# Altium schematic sheet node.SchDoc(NODE)
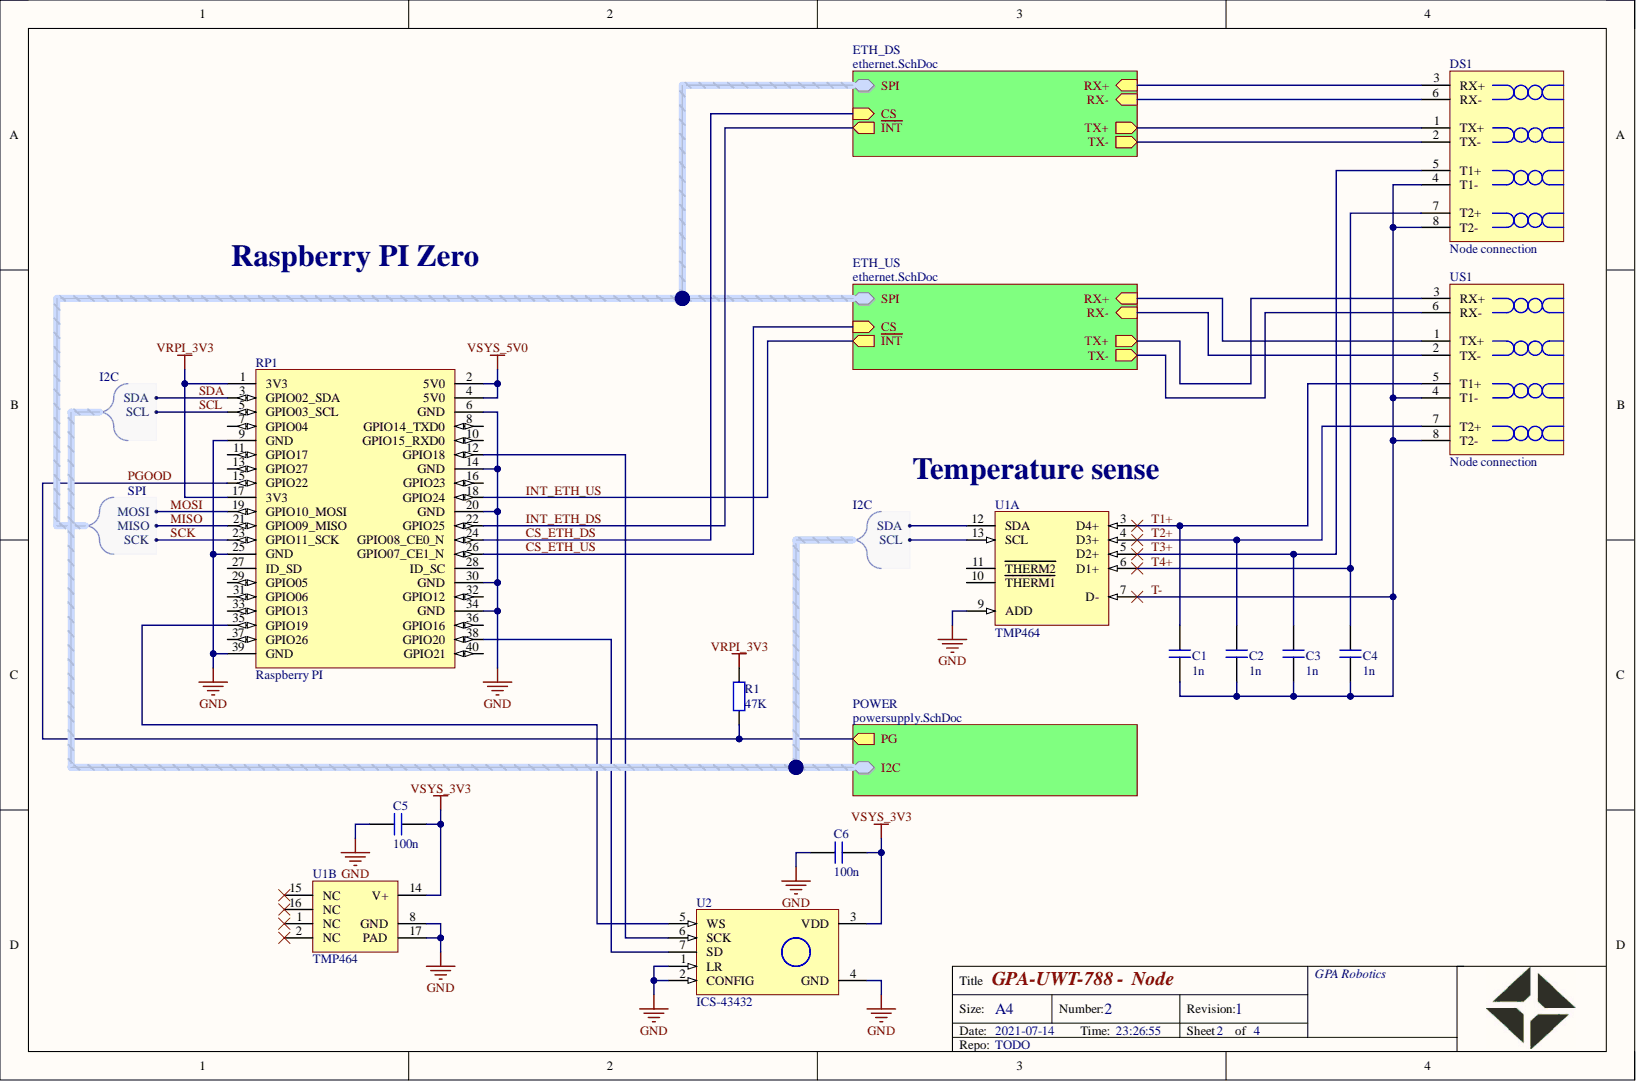
[diagram p0: node.SchDoc]

## Parts
C1  value 1n  [CAPC1005X06N]  (hidden comment: cap)
C2  value 1n  [CAPC1005X06N]  (hidden comment: cap)
C3  value 1n  [CAPC1005X06N]  (hidden comment: cap)
C4  value 1n  [CAPC1005X06N]  (hidden comment: cap)
C5  value 100n  [CAPC1005X06N]  (hidden comment: cap)
C6  value 100n  [CAPC1005X06N]  (hidden comment: cap)
DS1  Node connection  [node_connection]  (no BOM)
R1  value 47K  [RESC1005X04N]  (hidden comment: res)
RP1  Raspberry PI  [raspi_male_con]  (value not drawn)
U1  TMP464  [QFN50P300X300X100_HS-17N_TMP464]  (parts A,B)
U2  ICS-43432  [PSON65P300X110-6N]
US1  Node connection  [node_connection]  (no BOM)

## Nets
GND: C5.1 C6.1 RP1.6(GND) RP1.9(GND) RP1.14(GND) RP1.20(GND) RP1.25(GND) RP1.30(GND) RP1.34(GND) RP1.39(GND) U1.8(GND) U1.9(ADD) U1.17(PAD) U2.1(LR) U2.2(CONFIG) U2.4(GND)
VRPI_3V3: R1.2 RP1.1(3V3) RP1.17(3V3)
VSYS_3V3: C5.2 C6.2 U1.14(V+) U2.3(VDD)
VSYS_5V0: RP1.2(5V0) RP1.4(5V0)
CS_ETH_DS: RP1.24(GPIO08_CE0_N)
CS_ETH_US: RP1.26(GPIO07_CE1_N)
INT_ETH_DS: RP1.22(GPIO25)
INT_ETH_US: RP1.18(GPIO24)
MISO: RP1.21(GPIO09_MISO)
MOSI: RP1.19(GPIO10_MOSI)
PGOOD: R1.1 RP1.15(GPIO22)
SCK: RP1.23(GPIO11_SCK)
SCL: RP1.5(GPIO03_SCL) U1.13(SCL)
SDA: RP1.3(GPIO02_SDA) U1.12(SDA)
T1+: C1.2 U1.3(D4+) US1.5(T1+)
T2+: C2.2 U1.4(D3+) US1.7(T2+)
T3+: C3.2 DS1.5(T1+) U1.5(D2+)
T4+: C4.2 DS1.7(T2+) U1.6(D1+)
T-: C1.1 C2.1 C3.1 C4.1 DS1.4(T1-) DS1.8(T2-) U1.7(D-) US1.4(T1-) US1.8(T2-)
NetDS1_1: DS1.1(TX+)
NetDS1_2: DS1.2(TX-)
NetDS1_3: DS1.3(RX+)
NetDS1_6: DS1.6(RX-)
NetRP1_7: RP1.7(GPIO04)
NetRP1_8: RP1.8(GPIO14_TXD0)
NetRP1_10: RP1.10(GPIO15_RXD0)
NetRP1_11: RP1.11(GPIO17)
NetRP1_12: RP1.12(GPIO18) U2.6(SCK)
NetRP1_13: RP1.13(GPIO27)
NetRP1_16: RP1.16(GPIO23)
NetRP1_27: RP1.27(ID_SD)
NetRP1_28: RP1.28(ID_SC)
NetRP1_29: RP1.29(GPIO05)
NetRP1_31: RP1.31(GPIO06)
NetRP1_32: RP1.32(GPIO12)
NetRP1_33: RP1.33(GPIO13)
NetRP1_35: RP1.35(GPIO19) U2.5(WS)
NetRP1_36: RP1.36(GPIO16)
NetRP1_37: RP1.37(GPIO26)
NetRP1_38: RP1.38(GPIO20) U2.7(SD)
NetRP1_40: RP1.40(GPIO21)
NetU1_1: U1.1(NC)
NetU1_2: U1.2(NC)
NetU1_10: U1.10(THERM1)
NetU1_11: U1.11(THERM2)
NetU1_15: U1.15(NC)
NetU1_16: U1.16(NC)
NetUS1_1: US1.1(TX+)
NetUS1_2: US1.2(TX-)
NetUS1_3: US1.3(RX+)
NetUS1_6: US1.6(RX-)

## Library data of the parts
C1-C6 = cap: Capacitor; Component Kind: Standard; Library Name: lib_passives.IntLib
DS1 US1 = node_connection: Library Name: node_connection.SchLib
R1 = res: Resistor; Component Kind: Standard; Library Name: lib_passives.IntLib
RP1 = raspberry_2x20_con: Raspberry PI 2x20 connector; Component Kind: Standard; Library Name: lib_raspberry.IntLib
U1 = tmp464rgt: High-Accuracy 5-Channel (4-Remote and 1-Local) Temperature Sensor; Component Kind: Standard; ComponentLink1Description: Datasheet; ComponentLink1URL: https://www.ti.com/lit/ds/symlink/tmp464.pdf; Library Name: lib_ti.IntLib; Supplier 1: Digi-Key; Supplier 2: Digi-Key; Supplier Part Number 1: 296-46946-1-ND; Supplier Part Number 2: 296-50713-1-ND
U2 = ics-43432: Component Kind: Standard; Library Name: lib_invensense.IntLib
